# Altium schematic sheet FanController.SchDoc
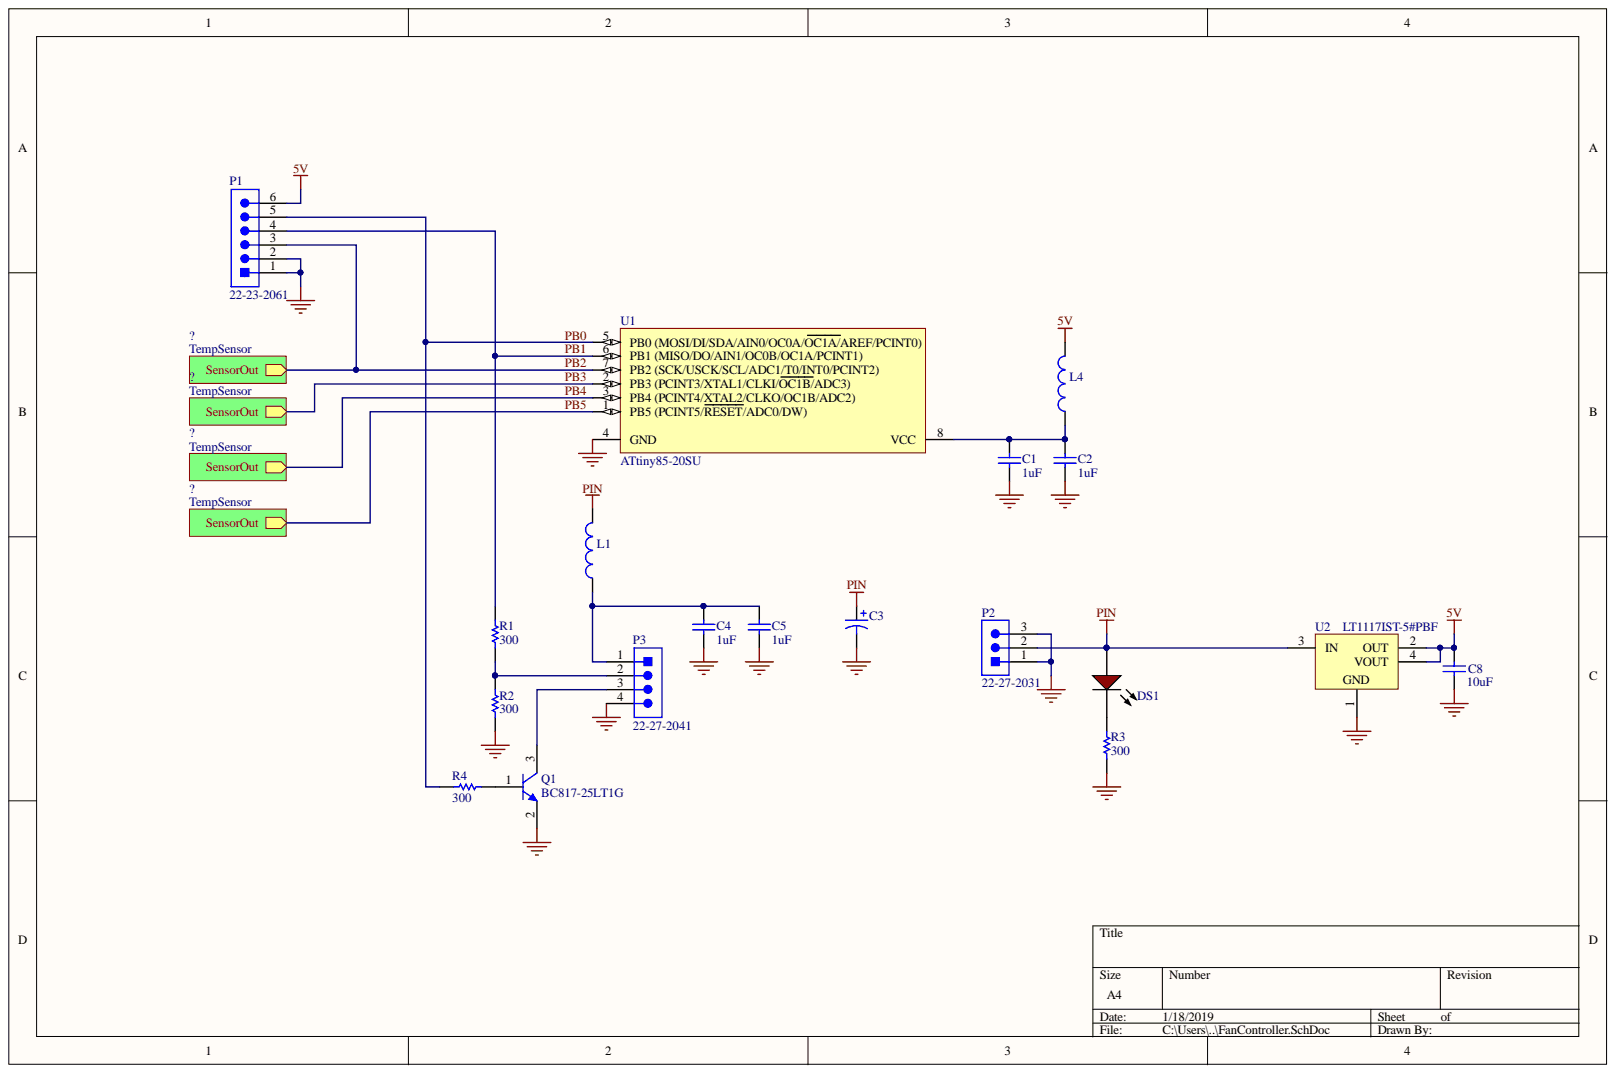
[diagram p0: FanController.SchDoc]

## Parts
C1  1uF  [CAPC0805(2012)145_N]
C2  1uF  [CAPC0805(2012)145_N]
C3  ECA-1JHG471  [PNSC-ECA-1JHG471]  (value not drawn)
C4  1uF  [CAPC0805(2012)145_N]
C5  1uF  [CAPC0805(2012)145_N]
C8  10uF  [CAPC1206(3216)130_L]
DS1  TLMS1100-GS08  [VISH-TLMX1100_V]  (value not drawn)
L1  FBMJ2125HM210NT  [INDC2013X105X50LL20T30]  (value not drawn)
L4  FBMJ2125HM210NT  [INDC2013X105X50LL20T30]  (value not drawn)
P1  22-23-2061  [MOLX-22-23-2061_V]
P2  22-27-2031  [MOLX-22-27-2031_V]
P3  22-27-2041  [MOLX-22-27-2041_V]
Q1  BC817-25LT1G  [ONSC-SOT-23-3-318-08_V]
R1  300  [RESC0805(2012)_M]
R2  300  [RESC0805(2012)_M]
R3  300  [RESC0805(2012)_M]
R4  300  [RESC0805(2012)_M]
U1  ATtiny85-20SU  [8S2_M]
U2  LT1117IST-5#PBF  [LT-ST-3-SOT-223_L]

## Nets
5V: C8.2 L4.2 P1.6 U2.2(OUT) U2.4(VOUT)
GND: C1.1 C2.1 C3.2 C4.1 C5.1 C8.1 P1.1 P1.2 P2.1 P2.3 P3.4 Q1.2 R2.1 R3.1 U1.4(GND) U2.1
PIN: C3.1 DS1.1 L1.2 P2.2 U2.3(IN)
PB0: P1.5 R4.1 U1.5(PB0_(MOSI/DI/SDA/AIN0/OC0A/OC1A/AREF/PCINT0))
PB1: P1.4 R1.2 U1.6(PB1_(MISO/DO/AIN1/OC0B/OC1A/PCINT1))
PB2: P1.3 U1.7(PB2_(SCK/USCK/SCL/ADC1/T0/INT0/PCINT2))
PB3: U1.2(PB3_(PCINT3/XTAL1/CLKI/OC1B/ADC3))
PB4: U1.3(PB4_(PCINT4/XTAL2/CLKO/OC1B/ADC2))
PB5: U1.1(PB5_(PCINT5/RESET/ADC0/DW))
NetC1_2: C1.2 C2.2 L4.1 U1.8(VCC)
NetC4_2: C4.2 C5.2 L1.1 P3.1
NetDS1_2: DS1.2 R3.2
NetP3_2: P3.2 R1.1 R2.2
NetP3_3: P3.3 Q1.3
NetQ1_1: Q1.1 R4.2

## Library data of the parts
C1 C2 C4 C5 = CMP-1036-04431-1: Case-EIA: 0805; Case-Metric: 2012; Rated Voltage: 100 V; Tolerance: Â±20%; Value: 100nF; Component Kind: Standard; Library Name: Altium Content Vault; Max Thickness: 1.45 mm; Technology: SMT
C3 = CMP-1665-00014-2: CAP ALUM 470UF 63V 20% RADIAL; Manufacturer: Panasonic; Component Kind: Standard; ComponentLink1Description: Datasheet; ComponentLink1URL: http://industrial.panasonic.com/cdbs/www-data/pdf/RDF0000/ABA0000C1038.pdf; Library Name: Altium Content Vault; PackageReference: ECA-1JHG471; PartNumber: ECA-1JHG471
C8 = CMP-1037-04970-1: CAP 10uF 10V -20% to +80% 1206 (3216 Metric) Thickness 1.3mm SMD; Case-EIA: 1206; Case-Metric: 3216; Component Kind: Standard; Library Name: Altium Content Vault; Max Thickness: 1.3 mm; Rated Voltage: 10 V; Technology: SMT; Tolerance: -20% to +80%; Value: 10uF
DS1 = CMP-1666-00027-1: LED, RED, 2.1 V, -40 to 100 degC, 2-Pin SMD (0603), RoHS, Tape and Reel; Capacitance: 12 pF; Resistance: 100000000000 Ohm; Tolerance: 5%; Type (Capacitors): C0G; Voltage Rating: 50 V; Case/Package: 0603; Case Code (Imperial): 0603; Case Code (Metric): 1608; Component Kind: Standard; ComponentLink1Description: Manufacturer URL; ComponentLink1URL: http://www.vishay.com/; ComponentLink2Description: Datasheet; ComponentLink2URL: http://www.vishay.com/docs/83173/tlmb1100.pdf; DatasheetVersion: Rev. 2.7, 03/2016; Height: 0.8 mm; Length: 1.6 mm; Library Name: Altium Content Vault; Max Operating Temperature: 125degC; Min Operating Temperature: -55degC; Mount: Surface Mount; PackageDescription: 2-Pin Surface Mount Device, Body 1.6 x 0.8 mm; Package Quantity: 4000; PackageReference: TLMX1100; Packaging: Tape and Reel; Pins: 2; Radiation Hardening: No; REACH SVHC: No SVHC; RoHSCompliant: True; Voltage Rating (DC): 50 V; Width: 0.8 mm
L1 L4 = CMP-1660-00003-1: Chip Inductor, 21 Ohm, +/- 30%, 6 A, -40 to 125 degC, 0805 (2012 Metric), RoHS, Tape and Reel; Case\Package: 0805; Core Material: Ferrite; Current Rating: 6 A; Impedance: 21 Ohm; Resistance: 4 mohm; Case Code (Imperial): 0805; Case Code (Metric): 2012; Component Kind: Standard; ComponentLink1Description: Manufacturer URL; ComponentLink1URL: http://www.t-yuden.com/; ComponentLink2Description: Datasheet; ComponentLink2URL: http://ds.yuden.co.jp/TYCOMPAS/ut/detail.do?productNo=FBMJ2125HM210NT&fileName=FBMJ2125HM210NT_SS&mode=specSheetDownload; DatasheetVersion: 08/2016; Height: 0.85 mm; Length: 2 mm; Library Name: Altium Content Vault; Max Current Rating: 6 A; Max Operating Temperature: 125 degC; Min Operating Temperature: -40 degC; Mount: Surface Mount; Mounting Technology: Surface Mount; PackageDescription: 2-Pin Surface Mount Device, Body 2 x 1.25 mm; Package Quantity: 1; PackageReference: 0805; Packaging: Tape and Reel; REACH SVHC: Unknown; RoHSCompliant: true; Tolerance: 30%; Width: 1.25 mm
P1 = CMP-2000-05536-1: Male Header, Pitch 2.54 mm, 1 x 6 Position, Height 10.67 mm, Tail Length 3.56 mm, 0 to 70 degC, RoHS, Bulk; Brand: Molex; Current Rating: 4A; Mounting Angle: Vertical; Number of Positions: 6; Pitch: 2.54mm; Voltage Rating: 250V; : 002223206122-23-2061-PBA-6373-06A102; Component Kind: Standard; Contact Material: Brass; Contact Plating: Tin; Datasheet URL: https://datasheet.ciiva.com/19460/232303-19460895.pdf; Datasheet Version: Rev. P, 09/2001; Factory Pack Quantity: 1000; Housing Material: Nylon; Library Name: Altium Content Vault; Manufacturer: Molex; Manufacturer URL: http://www.molex.com/; Mounting Style: ThroughHole; Mounting Technology: ThroughHole; Number of Positions / Contacts: 6; Number of Rows: 1; Package Description: Male Header, Pitch 2.54 mm, 1 x 6 Position, Height 10.67 mm, Tail Length 3.56 mm; Package Reference: 22-23-2061; Packaging: Bulk; Product Category: .100K.K.Connectors; Product Type: Headers; RoHS: Details; Series: 6373; Termination Style: ThroughHole; Tradename: KK; Type: PinStrip
P2 = CMP-2000-05538-1: Male Header, Pitch 2.54 mm, 1 x 3 Position, Height 11.7 mm, Tail Length 3.56 mm, -40 to 80 degC, RoHS, Bulk; Manufacturer: Molex; Current rating: 4A; Voltage rating: 250V; Component Kind: Standard; Contact material: Tinplatedbrass; Datasheet URL: http://www.molex.com/webdocs/datasheets/pdf/en-us/0022272031_PCB_HEADERS.pdf; Datasheet Version: 06/2016; Housing material: Nylon(UL94V-0); Library Name: Altium Content Vault; Manufacturer URL: http://www.molex.com/; Mounting Technology: ThroughHole; Operating temperature: 0°Cto+75°C; Package Description: Male Header, Pitch 2.54 mm, 1 x 3 Position, Height 11.7 mm, Tail Length 3.56 mm; Package Reference: 22-27-2031; Package URL: http://www.molex.com/pdm_docs/sd/022272031_sd.pdf
P3 = CMP-2000-05243-1: Male Header, Pitch 2.54 mm, 1 x 4 Position, Height 11.7 mm, Tail Length 3.56 mm, RoHS, Bulk; Manufacturer: Molex; Current rating: 4A; Voltage rating: 250V; Component Kind: Standard; Contact material: Tinplatedbrass; Datasheet URL: http://www.molex.com/pdm_docs/sd/022272041_sd.pdf; Datasheet Version: Rev. F, 06/2009; Housing material: Nylon(UL94V-0); Library Name: Altium Content Vault; Manufacturer URL: http://www.molex.com/; Operating temperature: 0degCto+75degC
Q1 = CMP-1048-00737-1: General Purpose Transistor, NPN Silicon, 3-Pin SOT-23, Pb-Free, Tape and Reel; Manufacturer: On Semiconductor; fT Min (MHz): 100; IC Continuous (A): 0.5; Component Kind: Standard; ComponentLink1Description: Manufacturer URL; ComponentLink1URL: http://www.onsemi.com/; ComponentLink2Description: Datasheet; ComponentLink2URL: http://www.onsemi.com/pub_link/Collateral/BC817-16LT1-D.PDF; ComponentLink3Description: Package Specification; ComponentLink3URL: http://www.onsemi.cn/pub_link/Collateral/318-08.PDF; DatasheetVersion: Rev. 12; Library Name: Altium Content Vault; Mounting Technology: Surface Mount; PackageDescription: 3-Pin Small Outline Transistor, Body 2.92 x 1.3 mm, Pitch 0.95 mm; PackageReference: SOT-23-3-318-08; PackageVersion: Rev. AP, 11/2009; Packing: Tape and Reel; PartNumber: BC817-25LT1G; Polarity: NPN; RoHS: TRUE
R1-R4 = CMP-1013-00449-1: 300R 0.125W 1% 0805 (2012 Metric)  SMD; Case-EIA: 0805; Case-Metric: 2012; Value: 300.00 Ohm; Component Kind: Standard; Library Name: Altium Content Vault; Power: 0.125 W; Technology: SMT; Tolerance: 1 %
U1 = CMP-0093-00173-2: 8-bit AVR Microcontroller, 20MHz, 2.7-5.5V, 8K Flash, 512 Bytes EEPROM, 512 Bytes SRAM, Industrial Grade (-40°C to 85°C), 8-Lead SOIC, Pb-Free; Component Kind: Standard; ComponentLink1Description: Manufacturer URL; ComponentLink1URL: http://www.atmel.com/; ComponentLink2Description: ATtiny25/45/85 Datasheet; ComponentLink2URL: http://www.atmel.com/dyn/resources/prod_documents/doc2586.pdf; ComponentLink3Description: ATtiny25/45/85 Summary; ComponentLink3URL: http://www.atmel.com/dyn/resources/prod_documents/2586S.pdf; DatasheetVersion: revN, Apr-2011; Library Name: Altium Content Vault; PackageReference: 8S2; PackageVersion: revF, Apr-2008; RevisionNote: DatasheetVersion updated. URLs added.
U2 = CMP-0476-01858-1: 0.8 A Low Dropout Positive Regulator, 2.45 to 15 V Vin, 5 V Vout, 3-pin SOT223 (ST-3), -40 to 125 degC, Pb-Free; Manufacturer: Linear Technology; Discrete Pass Element: no; Dropout Voltage(V): 1.1; Iout(mA): 800; Isupply(mA): 5; Number of Outputs: 1; Output Voltage: 5 V; PSRR(dB): 75; Vin Max(V): 15; Vin Min(V): 2.45; Component Kind: Standard; ComponentLink1Description: Manufacturer URL; ComponentLink1URL: http://www.linear.com/; ComponentLink2Description: Datasheet; ComponentLink2URL: http://cds.linear.com/docs/en/datasheet/1117fd.pdf; ComponentLink3Description: Package Specification; ComponentLink3URL: http://cds.linear.com/docs/en/packaging/SOT_3_05-08-1630.pdf; DatasheetVersion: Rev. D; Library Name: Altium Content Vault; Mounting Technology: Surface Mount; PackageDescription: 3-Pin Plastic SOT-223, 3.505 x 6.505 mm Body, Pitch 2.3 mm; PackageReference: ST-3; PackageVersion: Jun-10; PartNumber: LT1117IST-5#PBF; RoHS: TRUE
